# Altium schematic sheet Smart_CAN_1_2L.SchDoc
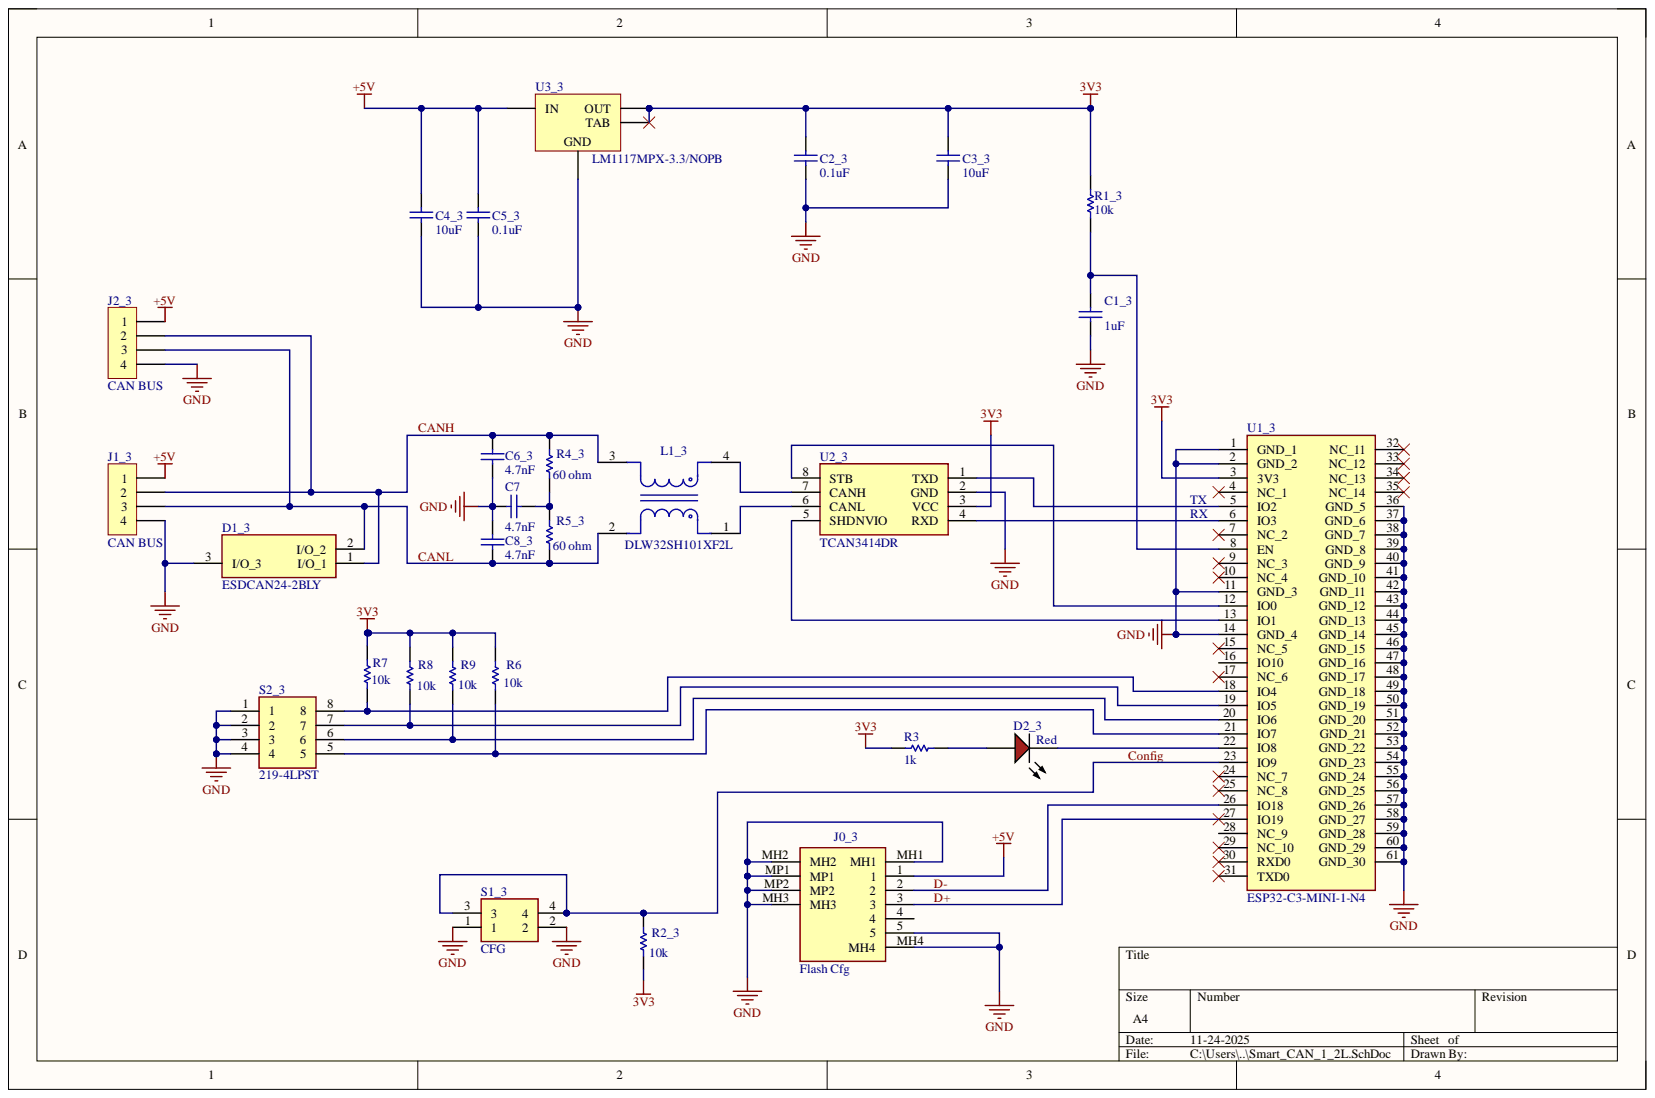
[diagram p0: Smart_CAN_1_2L.SchDoc]

## Parts
C1_3  1uF  [CAPC2013X135X45NL10T25]
C2_3  0.1uF  [CAPC2013X135X45NL10T25]
C3_3  10uF  [CAPC2013X135X45NL10T25]
C4_3  10uF  [CAPC2013X135X45NL10T25]
C5_3  0.1uF  [CAPC2013X135X45NL10T25]
C6_3  4.7nF  [CAPC2013X135X45NL10T25]
C7  4.7nF  [CAPC2013X135X45NL10T25]
C8_3  4.7nF  [CAPC2013X135X45NL10T25]
D1_3  ESDCAN24-2BLY  [SOT95P237X125-3N]
D2_3  Red  [VISH-TLMS1000_V]
J0_3  Flash Cfg  [101181940001LF]
J1_3  CAN BUS  [HDR1X4]
J2_3  CAN BUS  [HDR1X4]
L1_3  DLW32SH101XF2L  [FP-DLW32-0_2-t2_5-MFG]
R1_3  10k  [RESC2013X70X40NL20T20]
R2_3  10k  [RESC2013X70X40NL20T20]
R3  1k  [RESC2013X70X40NL20T20]
R4_3  60 ohm  [RESC2013X70X40NL20T20]
R5_3  60 ohm  [RESC2013X70X40NL20T20]
R6  10k  [RESC2013X70X40NL20T20]
R7  10k  [RESC2013X70X40NL20T20]
R8  10k  [RESC2013X70X40NL20T20]
R9  10k  [RESC2013X70X40NL20T20]
S1_3  CFG  [TL3365AF180QG]
S2_3  219-4LPST  [219-4LPST]
U1_3  ESP32-C3-MINI-1-N4  [ESP32C3MINI1N4-Extended]
U2_3  TCAN3414DR  [SOIC127P600X175-8N]
U3_3  LM1117MPX-3.3/NOPB  [DCY0004A_L]

## Nets
3V3: C2_3.2 C3_3.2 R1_3.1 R2_3.1 R3.2 R6.2 R7.2 R8.2 R9.2 U1_3.3(3V3) U2_3.3(VCC) U3_3.2(OUT) U3_3.4(TAB)
+5V: C4_3.2 C5_3.2 J0_3.1 J1_3.1 J2_3.1 U3_3.3(IN)
GND: C1_3.1 C2_3.1 C3_3.1 C4_3.1 C5_3.1 C6_3.2 C7.2 C8_3.1 D1_3.3 J0_3.5 J0_3.MH1 J0_3.MH2 J0_3.MH3 J0_3.MH4 J0_3.MP1 J0_3.MP2 J1_3.4 J2_3.4 S1_3.1 S1_3.2 S2_3.1 S2_3.2 S2_3.3 S2_3.4 U1_3.1(GND_1) U1_3.2(GND_2) U1_3.11(GND_3) U1_3.14(GND_4) U1_3.36(GND_5) U1_3.37(GND_6) U1_3.38(GND_7) U1_3.39(GND_8) U1_3.40(GND_9) U1_3.41(GND_10) U1_3.42(GND_11) U1_3.43(GND_12) U1_3.44(GND_13) U1_3.45(GND_14) U1_3.46(GND_15) U1_3.47(GND_16) U1_3.48(GND_17) U1_3.49(GND_18) U1_3.50(GND_19) U1_3.51(GND_20) U1_3.52(GND_21) U1_3.53(GND_22) U1_3.54(GND_23) U1_3.55(GND_24) U1_3.56(GND_25) U1_3.57(GND_26) U1_3.58(GND_27) U1_3.59(GND_28) U1_3.60(GND_29) U1_3.61(GND_30) U2_3.2(GND) U3_3.1
CANH: C6_3.1 D1_3.1 J1_3.2 J2_3.2 L1_3.3 R4_3.2
CANL: C8_3.2 D1_3.2 J1_3.3 J2_3.3 L1_3.2 R5_3.1
Config: R2_3.2 S1_3.3 S1_3.4 U1_3.23(IO9)
D+: J0_3.3 U1_3.27(IO19)
D-: J0_3.2 U1_3.26(IO18)
NetC1_3_2: C1_3.2 R1_3.2 U1_3.8(EN)
NetC7_1: C7.1 R4_3.1 R5_3.2
NetD2_3_1: D2_3.1 R3.1
NetD2_3_2: D2_3.2 U1_3.22(IO8)
NetL1_3_1: L1_3.1 U2_3.6(CANL)
NetL1_3_4: L1_3.4 U2_3.7(CANH)
NetR6_1: R6.1 S2_3.5 U1_3.21(IO7)
NetR7_1: R7.1 S2_3.8 U1_3.18(IO4)
NetR8_1: R8.1 S2_3.7 U1_3.19(IO5)
NetR9_1: R9.1 S2_3.6 U1_3.20(IO6)
NetU1_3_5: U1_3.5(IO2) U2_3.1(TXD)
NetU1_3_6: U1_3.6(IO3) U2_3.4(RXD)
NetU1_3_12: U1_3.12(IO0) U2_3.8(STB)
NetU1_3_13: U1_3.13(IO1) U2_3.5(SHDNVIO)

Not connected: J0_3 4; U1_3 4(NC_1) 7(NC_2) 9(NC_3) 10(NC_4) 15(NC_5) 16(IO10) 17(NC_6) 24(NC_7) 25(NC_8) 28(NC_9) 29(NC_10) 30(RXD0) 31(TXD0) 32(NC_11) 33(NC_12) 34(NC_13) 35(NC_14)

## Library data of the parts
C1_3 C2_3 C3_3 C4_3 C5_3 C7 = CMP-2007-03607-1: Component Kind: Standard; Library Name: Altium Content Vault
  C1_3: value: 1uF
  C2_3 C5_3: value: 0.1uF
  C3_3 C4_3: value: 10uF
  C7: value: 4.7nF
C6_3 C8_3 = CMP-2007-03607-1: value: 1nF; Component Kind: Standard; Library Name: Altium Content Vault
D1_3 = ESDCAN24-2BLY: TVS Diode (Bi-directional); Manufacturer: STMicroelectronics; Arrow Part Number: ESDCAN24-2BLY; Arrow Price/Stock: https://www.arrow.com/en/products/esdcan24-2bly/stmicroelectronics?utm_currency=USD&region=nac; Component Kind: Standard; Datasheet Link: https://www.st.com/resource/en/datasheet/esdcan24-2bly.pdf; Height: 1.25mm; Mouser Part Number: 511-ESDCAN24-2BLY; Mouser Price/Stock: https://www.mouser.co.uk/ProductDetail/STMicroelectronics/ESDCAN24-2BLY?qs=gNDSiZmRJS9IbRDJThIoAw%3D%3D; Part Number: ESDCAN24-2BLY
D2_3 = CMP-2000-07254-1: Low Current LED, 40 mW, 1.8 V, -40 to 100 degC, 2-Pin SMD (0603), RoHS, Tape and Reel; Manufacturer: Vishay; Part Number: TLMS1000-GS08; Automotive Qualification Standard: AEC-Q100; Bulb Size: 1.6mmx0.8mm; Forward Current If: 2mA; Forward Voltage: 1.8V; Lens Shape: Rectangular; Luminous Intensity: 4mcd; SVHC: ToBeAdvised; Viewing Angle: 80°; Wavelength Typ: 636nm; Component Kind: Standard; Datasheet URL: https://datasheet.ciiva.com/5798/1698881-5798337.pdf; Datasheet Version: Rev. 1.7, 02/2011; LED Colour: Red; LED Mounting: SMD; Library Name: Altium Content Vault; Manufacturer URL: http://www.vishay.com/; Mounting Technology: SurfaceMount; MSL: MSL2-1year; Package Description: 2-Pin Surface Mount Device, Body 1.6 x 0.8 mm; Package Reference: TLMS1000; Packaging: CutTape; Product Range: -; Value: Red
J0_3 = 10118194-0001LF: Connector; Manufacturer: Amphenol Communications Solutions; Arrow Part Number: 10118194-0001LF; Arrow Price/Stock: https://www.arrow.com/en/products/10118194-0001lf/amphenol-fci?utm_currency=USD&region=nac; Component Kind: Standard; Datasheet Link: https://www.amphenol-cs.com/micro-usb-101181940001lf.html; Height: 3.25mm; Part Number: 10118194-0001LF
J1_3 J2_3 = Header 4: Header, 4-Pin; Component Kind: Standard; LatestRevisionDate: 17-Jul-2002; LatestRevisionNote: Re-released for DXP Platform.; Signal Integrity: Connector; Library Name: Miscellaneous Connectors HZ.IntLib; Publisher: Altium Limited
L1_3 = CMP-06045-000067-1: CMC SMD 100UH 115MA POWRTRN, AUT; Manufacturer: Murata; Component Kind: Standard; Library Name: Altium Content Vault; Part Number: DLW32SH101XF2L; value: 100uh
R1_3 R2_3 R6-R9 = CMP-1665-00002-5: PartNumber: ERJ-6GEY0R00V; Resistance: 0 Ohm; Tolerance: 5%; Case Code (Imperial): 0805; Case Code (Metric): 2012; Case/Package: 0805; Component Kind: Standard; ComponentLink1Description: Manufacturer URL; ComponentLink1URL: http://www.panasonic.com/; ComponentLink2Description: Datasheet; ComponentLink2URL: http://industrial.panasonic.com/cdbs/www-data/pdf/RDA0000/AOA0000C84.pdf; DatasheetVersion: 03/2015; Height: 0.6 mm; Length: 2 mm; Library Name: Altium Content Vault; Max Operating Temperature: 155 degC; Min Operating Temperature: -55 degC; Mounting Technology: Surface Mount; Package Quantity: 5000; PackageDescription: 2-Pin Surface Mount Device, Body 2 x 1.25 mm; Packaging: Tape and Reel; Radiation Hardening: No; REACH SVHC: No SVHC; RoHSCompliant: True; value: 10k; Width: 1.25 mm
R3 = CMP-1665-00002-5: PartNumber: ERJ-6GEY0R00V; Resistance: 0 Ohm; Tolerance: 5%; value: 10k; Case Code (Imperial): 0805; Case Code (Metric): 2012; Case/Package: 0805; Component Kind: Standard; ComponentLink1Description: Manufacturer URL; ComponentLink1URL: http://www.panasonic.com/; ComponentLink2Description: Datasheet; ComponentLink2URL: http://industrial.panasonic.com/cdbs/www-data/pdf/RDA0000/AOA0000C84.pdf; DatasheetVersion: 03/2015; Height: 0.6 mm; Length: 2 mm; Library Name: Altium Content Vault; Max Operating Temperature: 155 degC; Min Operating Temperature: -55 degC; Mounting Technology: Surface Mount; Package Quantity: 5000; PackageDescription: 2-Pin Surface Mount Device, Body 2 x 1.25 mm; Packaging: Tape and Reel; Radiation Hardening: No; REACH SVHC: No SVHC; RoHSCompliant: True; Width: 1.25 mm
R4_3 R5_3 = CMP-1665-00002-5: PartNumber: ERJ-6GEY0R00V; Resistance: 0 Ohm; Tolerance: 5%; value: 62R; Case Code (Imperial): 0805; Case Code (Metric): 2012; Case/Package: 0805; Component Kind: Standard; ComponentLink1Description: Manufacturer URL; ComponentLink1URL: http://www.panasonic.com/; ComponentLink2Description: Datasheet; ComponentLink2URL: http://industrial.panasonic.com/cdbs/www-data/pdf/RDA0000/AOA0000C84.pdf; DatasheetVersion: 03/2015; Height: 0.6 mm; Length: 2 mm; Library Name: Altium Content Vault; Max Operating Temperature: 155 degC; Min Operating Temperature: -55 degC; Mounting Technology: Surface Mount; Package Quantity: 5000; PackageDescription: 2-Pin Surface Mount Device, Body 2 x 1.25 mm; Packaging: Tape and Reel; Radiation Hardening: No; REACH SVHC: No SVHC; RoHSCompliant: True; Width: 1.25 mm
S1_3 = TL3365AF180QG: Switch; Manufacturer: E-Switch; Component Kind: Standard; Datasheet Link: https://configured-product-images.s3.amazonaws.com/2D/specs/TL3365AF180QG.pdf; Height: 2.75mm; Mouser Part Number: 612-TL3365AF180QG; Mouser Price/Stock: https://www.mouser.co.uk/ProductDetail/E-Switch/TL3365AF180QG?qs=xQzBpF%2FAFTo%252BA4IL7l2Rvw%3D%3D; Part Number: TL3365AF180QG
S2_3 = 219-4LPST: Switch; Manufacturer_Name: CTS; Arrow Part Number: 219-4LPST; Arrow Price/Stock: https://www.arrow.com/en/products/219-4lpst/cts; Component Kind: Standard; Datasheet Link: https://www.ctscorp.com/Files/DataSheets/Switches/DIP-Switches/CTS-Switches-DIP-219-Series-Datasheet.pdf; Manufacturer_Part_Number: 219-4LPST; Mouser Part Number: 774-2194LPST; Mouser Price/Stock: https://www.mouser.co.uk/ProductDetail/CTS-Electronic-Components/219-4LPST?qs=qNzHFtQhdJ%252BX5DxjUF%252BqAA%3D%3D
U1_3 = ESP32-C3-MINI-1-N4: Integrated Circuit; Manufacturer: Espressif Systems; Component Kind: Standard; Datasheet Link: https://www.mouser.com/datasheet/2/891/Espressif_ESP32_C3_MINI_1_Datasheet-2006822.pdf; Height: 2.55mm; Library Name: SamacSys.SchLib; Mouser Part Number: 356-ESP32-C3-MINI1N4; Mouser Price/Stock: https://www.mouser.co.uk/ProductDetail/Espressif-Systems/ESP32-C3-MINI-1-N4?qs=stqOd1AaK7%252B%2FpH3qqyGehA%3D%3D; Part Number: ESP32-C3-MINI-1-N4
U2_3 = TCAN3414DR: Integrated Circuit; Manufacturer: Texas Instruments; Arrow Part Number: TCAN3414DR; Arrow Price/Stock: https://www.arrow.com/en/products/tcan3414dr/texas-instruments?utm_currency=USD&region=nac; Component Kind: Standard; Datasheet Link: https://www.ti.com/lit/ds/symlink/tcan3414.pdf?ts=1713723144569&ref_url=https%253A%252F%252Fwww.mouser.ch%252F; Height: 1.75mm; Mouser Part Number: 595-TCAN3414DR; Mouser Price/Stock: https://www.mouser.co.uk/ProductDetail/Texas-Instruments/TCAN3414DR?qs=mELouGlnn3egF2AojRJddw%3D%3D; Part Number: TCAN3414DR
U3_3 = CMP-0062-01639-4: 800mA Low-Dropout Linear Regulator, 4-pin SOT-223, Pb-Free; Manufacturer: Texas Instruments; CompType: LDO IC; High-Reliability: Y; PackageTopMarking: XYTT*N05A; PowerWise: N; Code_IPC: SOT223-4; Code_JEDEC: TO-261-AA; Component Kind: Standard; ComponentLink1Description: Datasheet URL; ComponentLink1URL: http://www.ti.com/general/docs/lit/getliterature.tsp?genericPartNumber=LM1117-N&fileType=pdf; Library Name: Altium Content Vault; PackageDescription: Molded SOT-223, 6.50 x 3.56 x 1.6mm Body, 4 Lead, 2.3mm Pitch; PackageReference: DCY0004A; PartNumber: LM1117MPX-3.3/NOPB; PinCount: 4; RoHS: Y
